# Altium schematic sheet FastRelay.SchDoc
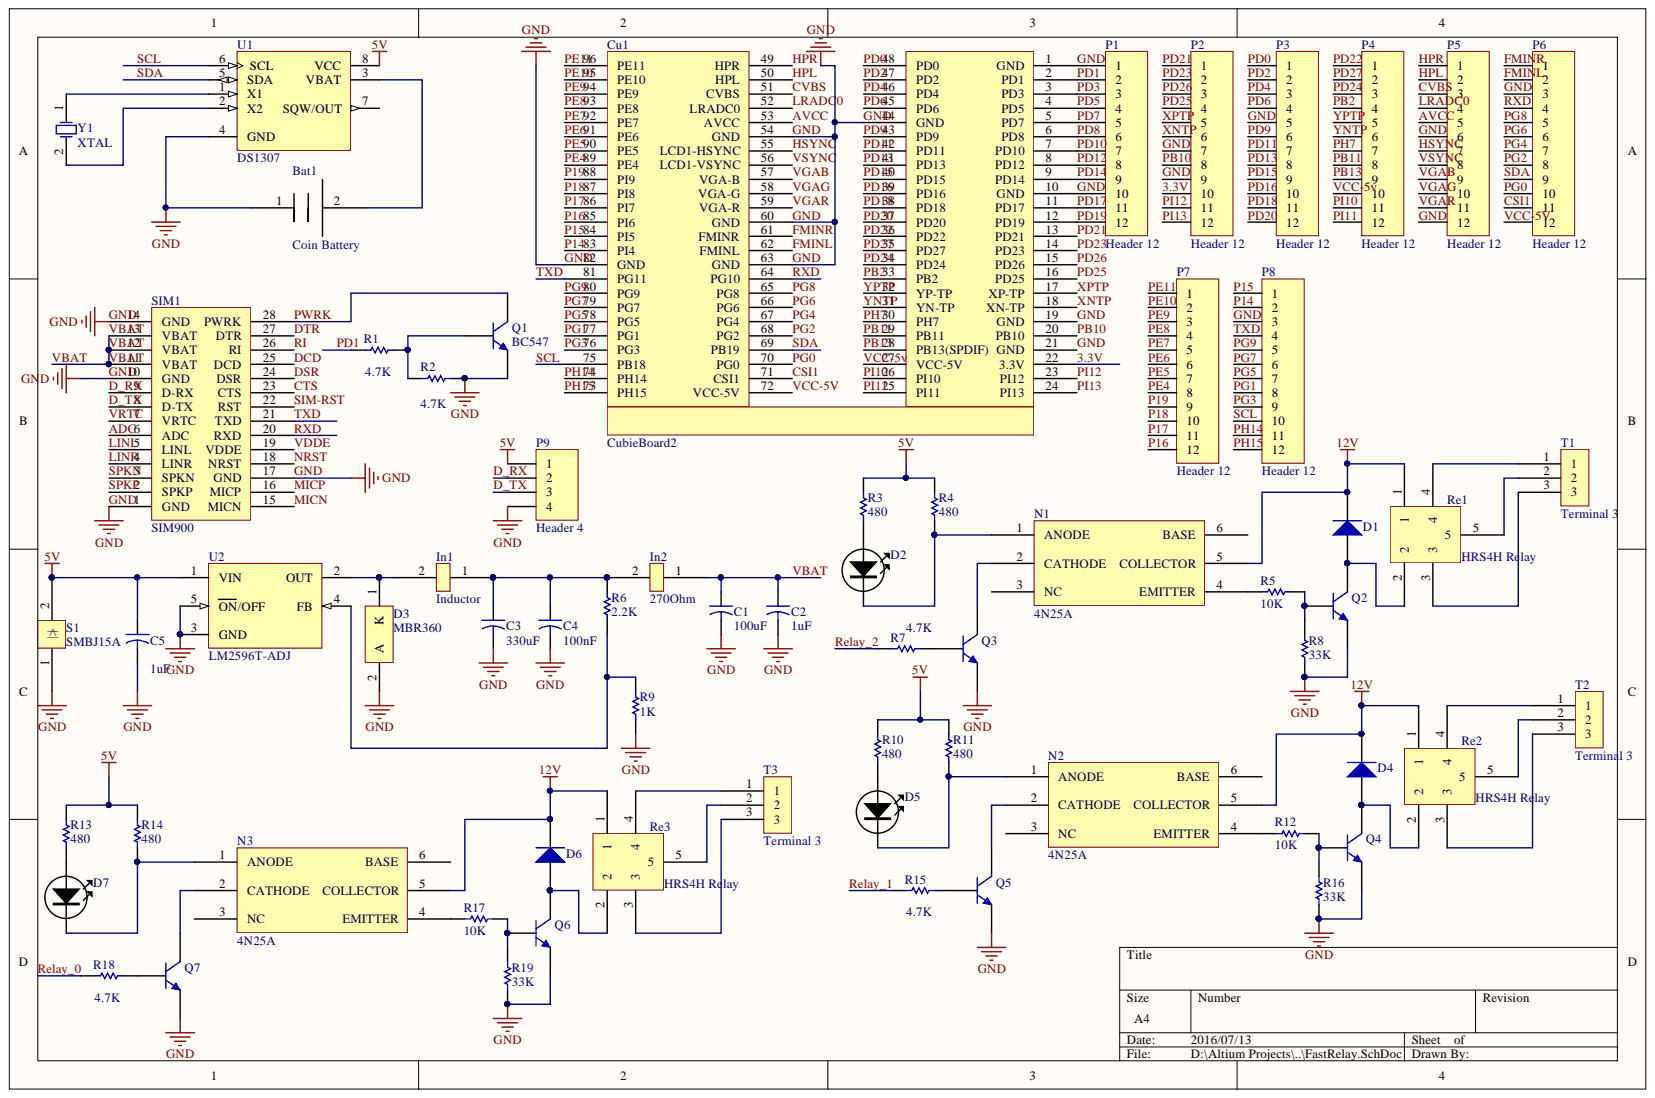
[diagram p0: FastRelay.SchDoc]

## Parts
Bat1  Coin Battery  [CoinBattery]
C1  value 100uF  [CAPR5-4X5]  (hidden comment: Cap2)
C2  value 1uF  [CAPR5-4X5]  (hidden comment: Cap2)
C3  value 330uF  [CAPR5-4X5]  (hidden comment: Cap2)
C4  value 100nF  [CAPR5-4X5]  (hidden comment: Cap2)
C5  value 1uF  [CAPR5-4X5]  (hidden comment: Cap2)
Cu1  CubieBoard2  [CubieBoard2]
D1  Diode 1N4007  [DO-41]  (value not drawn)
D2  LED-GREEN-3MM  [LED-3MM-GREEN]  (value not drawn)
D3  MBR360  [ONSC-AXIAL_LEAD-2-267-05_V]
D4  Diode 1N4007  [DO-41]  (value not drawn)
D5  LED-GREEN-3MM  [LED-3MM-GREEN]  (value not drawn)
D6  Diode 1N4007  [DO-41]  (value not drawn)
D7  LED-GREEN-3MM  [LED-3MM-GREEN]  (value not drawn)
In1  Inductor  [Inductor]
In2  270Ohm  [Inductor]
N1  4N25A  [4N25A]
N2  4N25A  [4N25A]
N3  4N25A  [4N25A]
P1  Header 12  [HDR1X12]
P2  Header 12  [HDR1X12]
P3  Header 12  [HDR1X12]
P4  Header 12  [HDR1X12]
P5  Header 12  [HDR1X12]
P6  Header 12  [HDR1X12]
P7  Header 12  [HDR1X12]
P8  Header 12  [HDR1X12]
P9  Header 4  [HDR1X4]
Q1  BC547  [SOT54]
Q2  BC547  [SOT54]  (value not drawn)
Q3  BC547  [SOT54]  (value not drawn)
Q4  BC547  [SOT54]  (value not drawn)
Q5  BC547  [SOT54]  (value not drawn)
Q6  BC547  [SOT54]  (value not drawn)
Q7  BC547  [SOT54]  (value not drawn)
R1  value 4.7K  [AXIAL-0.3]  (hidden comment: Res1)
R2  value 4.7K  [AXIAL-0.3]  (hidden comment: Res1)
R3  value 480  [AXIAL-0.3]  (hidden comment: Res1)
R4  value 480  [AXIAL-0.3]  (hidden comment: Res1)
R5  value 10K  [AXIAL-0.3]  (hidden comment: Res1)
R6  value 2.2K  [AXIAL-0.3]  (hidden comment: Res1)
R7  value 4.7K  [AXIAL-0.3]  (hidden comment: Res1)
R8  value 33K  [AXIAL-0.3]  (hidden comment: Res1)
R9  value 1K  [AXIAL-0.3]  (hidden comment: Res1)
R10  value 480  [AXIAL-0.3]  (hidden comment: Res1)
R11  value 480  [AXIAL-0.3]  (hidden comment: Res1)
R12  value 10K  [AXIAL-0.3]  (hidden comment: Res1)
R13  value 480  [AXIAL-0.3]  (hidden comment: Res1)
R14  value 480  [AXIAL-0.3]  (hidden comment: Res1)
R15  value 4.7K  [AXIAL-0.3]  (hidden comment: Res1)
R16  value 33K  [AXIAL-0.3]  (hidden comment: Res1)
R17  value 10K  [AXIAL-0.3]  (hidden comment: Res1)
R18  value 4.7K  [AXIAL-0.3]  (hidden comment: Res1)
R19  value 33K  [AXIAL-0.3]  (hidden comment: Res1)
Re1  HRS4H Relay  [HRS4H-Relay]
Re2  HRS4H Relay  [HRS4H-Relay]
Re3  HRS4H Relay  [HRS4H-Relay]
S1  SMBJ15A  [SMBJ15A]
SIM1  SIM900  [SIM900]
T1  Terminal 3  [Terminal3]
T2  Terminal 3  [Terminal3]
T3  Terminal 3  [Terminal3]
U1  DS1307  [DIP8]
U2  LM2596T-ADJ  [T05D]
Y1  XTAL  [R38]

## Nets
5V: C5.1 P9.1 R3.2 R4.2 R10.2 R11.2 R13.2 R14.2 S1.2 U1.8(VCC) U2.1(VIN)
12V: D1.2 D4.2 D6.2 N1.5(COLLECTOR) N2.5(COLLECTOR) N3.5(COLLECTOR) Re1.1 Re2.1 Re3.1
3.3V: Cu1.22(3.3V) P2.10
ADC: SIM1.6(ADC)
AVCC: Cu1.53 P5.5
CSI1: Cu1.71 P6.11
CTS: SIM1.23(CTS)
CVBS: Cu1.51 P5.3
D_RX: P9.2 SIM1.9(D-RX)
D_TX: P9.3 SIM1.8(D-TX)
DCD: SIM1.25(DCD)
DSR: SIM1.24(DSR)
DTR: SIM1.27(DTR)
FMINL: Cu1.62 P6.2
FMINR: Cu1.61(FMINR) P6.1
GND: Bat1.1 C1.2 C2.2 C3.2 C4.2 C5.2 Cu1.1(GND) Cu1.10(GND) Cu1.19(GND) Cu1.21(GND) Cu1.44 Cu1.54(GND) Cu1.60 Cu1.63 Cu1.82(GND) D3.2 P1.1 P1.10 P2.7 P2.9 P3.5 P5.6 P5.12 P6.3 P8.3 P9.4 Q1.1 Q2.1 Q3.1 Q4.1 Q5.1 Q6.1 Q7.1 R2.2 R8.2 R9.1 R16.2 R19.2 S1.1 SIM1.1(GND) SIM1.10(GND) SIM1.14(GND) SIM1.17(GND) U1.4(GND) U2.3(GND) U2.5(ON/OFF)
HPL: Cu1.50 P5.2
HPR: Cu1.49(HPR) P5.1
HSYNC: Cu1.55 P5.7
LINL: SIM1.5(LINL)
LINR: SIM1.4(LINR)
LRADC0: Cu1.52 P5.4
MICN: SIM1.15(MICN)
MICP: SIM1.16(MICP)
NRST: SIM1.18(NRST)
P14: Cu1.83(PI4) P8.2
P15: Cu1.84(PI5) P8.1
P16: Cu1.85(PI6) P7.12
P17: Cu1.86(PI7) P7.11
P18: Cu1.87(PI8) P7.10
P19: Cu1.88(PI9) P7.9
PB2: Cu1.33 P4.4
PB10: Cu1.20(PB10) P2.8
PB11: Cu1.29 P4.8
PB13: Cu1.28 P4.9
PD0: Cu1.48 P3.1
PD1: Cu1.2(PD1) P1.2 R1.1
PD2: Cu1.47 P3.2
PD3: Cu1.3(PD3) P1.3
PD4: Cu1.46 P3.3
PD5: Cu1.4(PD5) P1.4
PD6: Cu1.45 P3.4
PD7: Cu1.5(PD7) P1.5
PD8: Cu1.6(PD8) P1.6
PD9: Cu1.43 P3.6
PD10: Cu1.7(PD10) P1.7
PD11: Cu1.42 P3.7
PD12: Cu1.8(PD12) P1.8
PD13: Cu1.41 P3.8
PD14: Cu1.9(PD14) P1.9
PD15: Cu1.40 P3.9
PD16: Cu1.39 P3.10
PD17: Cu1.11(PD17) P1.11
PD18: Cu1.38 P3.11
PD19: Cu1.12(PD19) P1.12
PD20: Cu1.37 P3.12
PD21: Cu1.13(PD21) P2.1
PD22: Cu1.36 P4.1
PD23: Cu1.14(PD23) P2.2
PD24: Cu1.34 P4.3
PD25: Cu1.16(PD25) P2.4
PD26: Cu1.15(PD26) P2.3
PD27: Cu1.35 P4.2
PE4: Cu1.89(PE4) P7.8
PE5: Cu1.90(PE5) P7.7
PE6: Cu1.91(PE6) P7.6
PE7: Cu1.92(PE7) P7.5
PE8: Cu1.93(PE8) P7.4
PE9: Cu1.94(PE9) P7.3
PE10: Cu1.95(PE10) P7.2
PE11: Cu1.96(PE11) P7.1
PG0: Cu1.70 P6.10
PG1: Cu1.77(PG1) P8.8
PG2: Cu1.68 P6.8
PG3: Cu1.76(PG3) P8.9
PG4: Cu1.67 P6.7
PG5: Cu1.78(PG5) P8.7
PG6: Cu1.66(PG6) P6.6
PG7: Cu1.79(PG7) P8.6
PG8: Cu1.65 P6.5
PG9: Cu1.80(PG9) P8.5
PH7: Cu1.30 P4.7
PH14: Cu1.74(PH14) P8.11
PH15: Cu1.73(PH15) P8.12
PI10: Cu1.26 P4.11
PI11: Cu1.25 P4.12
PI12: Cu1.23(PI12) P2.11
PI13: Cu1.24(PI13) P2.12
PWRK: Q1.3 SIM1.28(PWRK)
RELAY_0: R18.2
RELAY_1: R15.2
RELAY_2: R7.2
RI: SIM1.26(RI)
RXD: Cu1.64(RXD) P6.4 SIM1.20(RXD)
SCL: Cu1.75(PB18) P8.10 U1.6(SCL)
SDA: Cu1.69(SDA) P6.9 U1.5(SDA)
SIM-RST: SIM1.22(RST)
SPKN: SIM1.3(SPKN)
SPKP: SIM1.2(SPKP)
TXD: Cu1.81(PG11) P8.4 SIM1.21(TXD)
VBAT: C1.1 C2.1 In2.1 SIM1.11(VBAT) SIM1.12(VBAT) SIM1.13(VBAT)
VCC-5V: Cu1.27 Cu1.72 P4.10 P6.12
VDDE: SIM1.19(VDDE)
VGAB: Cu1.57 P5.9
VGAG: Cu1.58 P5.10
VGAR: Cu1.59(VGAR) P5.11
VRTC: SIM1.7(VRTC)
VSYNC: Cu1.56 P5.8
XNTP: Cu1.18(XN-TP) P2.6
XPTP: Cu1.17(XP-TP) P2.5
YNTP: Cu1.31 P4.6
YPTP: Cu1.32 P4.5
NetBat1_2: Bat1.2 U1.3(VBAT)
NetC3_1: C3.1 C4.1 In1.1 In2.2 R6.2
NetD1_1: D1.1 Q2.3 Re1.2
NetD2_A: D2.A R3.1
NetD2_K: D2.K N1.1(ANODE) R4.1
NetD3_1: D3.1 In1.2 U2.2(OUT)
NetD4_1: D4.1 Q4.3 Re2.2
NetD5_A: D5.A R10.1
NetD5_K: D5.K N2.1(ANODE) R11.1
NetD6_1: D6.1 Q6.3 Re3.2
NetD7_A: D7.A R13.1
NetD7_K: D7.K N3.1(ANODE) R14.1
NetN1_2: N1.2(CATHODE) Q3.3
NetN1_4: N1.4(EMITTER) R5.2
NetN2_2: N2.2(CATHODE) Q5.3
NetN2_4: N2.4(EMITTER) R12.2
NetN3_2: N3.2(CATHODE) Q7.3
NetN3_4: N3.4(EMITTER) R17.2
NetQ1_2: Q1.2 R1.2 R2.1
NetQ2_2: Q2.2 R5.1 R8.1
NetQ3_2: Q3.2 R7.1
NetQ4_2: Q4.2 R12.1 R16.1
NetQ5_2: Q5.2 R15.1
NetQ6_2: Q6.2 R17.1 R19.1
NetQ7_2: Q7.2 R18.1
NetR6_1: R6.1 R9.2 U2.4(FB)
NetRe1_3: Re1.3 T1.3
NetRe1_4: Re1.4 T1.1
NetRe1_5: Re1.5 T1.2
NetRe2_3: Re2.3 T2.3
NetRe2_4: Re2.4 T2.1
NetRe2_5: Re2.5 T2.2
NetRe3_3: Re3.3 T3.3
NetRe3_4: Re3.4 T3.1
NetRe3_5: Re3.5 T3.2
NetU1_1: U1.1(X1) Y1.1
NetU1_2: U1.2(X2) Y1.2

Not connected: N1 3(NC) 6(BASE); N2 3(NC) 6(BASE); N3 3(NC) 6(BASE); U1 7(SQW/OUT)

## Library data of the parts
C1-C5 = Cap2: Capacitor; Component Kind: Standard; Library Name: Miscellaneous Devices.IntLib; PackageDescription: Capacitor; 2 Leads; PackageReference: CAPR5-4X5; Published: 23-Sep-2002; Signal Integrity: Cap; Simulation: CAP; Publisher: Altium Limited
D1 D4 D6 = Diode 1N4007: 1 Amp General Purpose Rectifier; Code_JEDEC: DO-204-AL; Component Kind: Standard; ComponentLink1Description: Manufacturer Link; ComponentLink1URL: http://www.fairchildsemi.com/; ComponentLink2Description: Datasheet; ComponentLink2URL: http://www.fairchildsemi.com/ds/1N%2F1N4001.pdf; DatasheetVersion: 1998; LatestRevisionDate: 17-Jul-2002; LatestRevisionNote: Re-released for DXP Platform.; Library Name: Miscellaneous Devices.IntLib; PackageDescription: Diode, Axial; Body 5.2 x 2.7 mm (LxDia. max), Lead Dia. 0.86 mm (max); PackageReference: DO-41; PackageVersion: Aug-1999; Published: 8-Jun-2000; Signal Integrity: 1N4007; Simulation: 1N4007; Publisher: Altium Limited
D3 = MBR360: Rectifier, 2-Pin Axial_Lead, Bulk Bag; Manufacturer: On Semiconductor; Configuration: Single; IFSM Max (A): 80; Component Kind: Standard; ComponentLink1Description: Manufacturer URL; ComponentLink1URL: http://www.onsemi.com/; ComponentLink2Description: Datasheet; ComponentLink2URL: http://www.onsemi.cn/pub_link/Collateral/MBR350-D.PDF; ComponentLink3Description: Package Specification; ComponentLink3URL: http://www.onsemi.com/pub_link/Collateral/267-05.PDF; DatasheetVersion: Rev. 6; Library Name: ON Semi Schottky Diodes & Schottky Rectifiers.IntLib; Mounting Technology: Through Hole; PackageDescription: 2-Pin, Axial Lead, Diameter 5.05 mm; PackageReference: AXIAL_LEAD-2-267-05; PackageVersion: Rev. G, 06/2000; Packing: Bulk Bag; PartNumber: MBR360; RoHS: FALSE; Supplier 1: Digi-Key; Supplier Part Number 1: MBR360OS-ND
In1 = Inductor: 100uF; Component Kind: Standard; Library Name: Inductor.SchLib
In2 = Inductor: Component Kind: Standard; Description: Inductor; Library Name: Inductor.SchLib
P1-P8 = Header 12: Header, 12-Pin; Component Kind: Standard; LatestRevisionDate: 17-Jul-2002; LatestRevisionNote: Re-released for DXP Platform.; Library Name: Miscellaneous Connectors.IntLib; Signal Integrity: Connector; Publisher: Altium Limited
P9 = Header 4: Header, 4-Pin; Component Kind: Standard; LatestRevisionDate: 17-Jul-2002; LatestRevisionNote: Re-released for DXP Platform.; Library Name: Miscellaneous Connectors.IntLib; Signal Integrity: Connector; Publisher: Altium Limited
Q1-Q7 = BC547: NPN General-purpose Transistor; Code_JEDEC: TO-92; Code_JEITA: SC-43; Component Kind: Standard; ComponentLink1Description: Manufacturer Link; ComponentLink1URL: http://www.semiconductors.philips.com/; ComponentLink2Description: Datasheet; ComponentLink2URL: http://www.semiconductors.philips.com/acrobat/datasheets/BC546_547_3.pdf; DatasheetVersion: 15-Apr-1999; LatestRevisionDate: 12-Dec-2006; LatestRevisionNote: Footprint pad sequence fixed.; Library Name: Philips Discrete BJT - Low Power.IntLib; PackageDescription: Plastic Single-Ended Leaded (through hole) package; 3 Leads (TO-92); PackageReference: SOT54; PackageVersion: Feb-1997; Published: 17-Sep-2003; Signal Integrity: BC547; Publisher: Altium Limited
R1-R19 = Res1: Resistor; Component Kind: Standard; LatestRevisionDate: 17-Jul-2002; LatestRevisionNote: Re-released for DXP Platform.; Library Name: Miscellaneous Devices.IntLib; PackageDescription: Axial Device, Thru-Hole; 2 Leads; 0.3 in Pin Spacing; PackageReference: AXIAL-0.3; Published: 8-Jun-2000; Signal Integrity: Res; Simulation: RESISTOR; Publisher: Altium Limited
U1 = DS1307: 64 X 8 Serial Real-Time Clock; Component Kind: Standard; ComponentLink1Description: Manufacturer Link; ComponentLink1URL: http://www.maxim-ic.com/; ComponentLink2Description: Datasheet; ComponentLink2URL: http://pdfserv.maxim-ic.com/en/ds/DS1307.pdf; ComponentLink3Description: Discontinuation Notice; ComponentLink3URL: http://www.maxim-ic.com/qa/pdfs/non-obsolescence-letter.pdf; DatasheetVersion: 10-Aug-1999; LatestRevisionDate: 17-Jul-2002; LatestRevisionNote: Re-released for DXP Platform.; Library Name: Dallas Peripheral Real Time Clock.IntLib; PackageDescription: DIP, 8-Pin, Row Spacing 7.62 mm, Pitch 2.54 mm; PackageReference: DIP8; PackageVersion: 1999; Published: 13-Oct-2000; Publisher: Altium Limited
U2 = LM2596T-ADJ: SIMPLE SWITCHER® Power Converter 150 KHz 3A Step-Down Voltage Regulator, 5-pin TO-220; Manufacturer: National Semiconductor; High-Reliability: Y; PackageTopMarking: NSUZXYTTE#*LM2596T*-ADJ P+; PowerWise: N; Component Kind: Standard; ComponentLink1Description: Manufacturer URL; ComponentLink1URL: http://www.national.com/; ComponentLink2Description: LM2596 Datasheet; ComponentLink2URL: http://www.national.com/ds/LM/LM2596.pdf; ComponentLink3Description: LM2596 Web Page; ComponentLink3URL: http://www.national.com/pf/LM/LM2596.html; ComponentLink4Description: T05D Package Page; ComponentLink4URL: http://www.national.com/packaging/folders/t05d.html; CompType: Switcher; DatasheetVersion: May-2002; Library Name: National Semiconductor Switcher.IntLib; PackageDescription: TO-220, Molded, Stagger Bend, 5 Lead; PackageReference: T05D; PackageVersion: revA, Oct-1989; PartNumber: LM2596T-ADJ; PinCount: 5; RoHS: N
Y1 = XTAL: Crystal Oscillator; Component Kind: Standard; LatestRevisionDate: 17-Jul-2002; LatestRevisionNote: Re-released for DXP Platform.; Library Name: Miscellaneous Devices.IntLib; PackageDescription: Crystal, Thru-Hole; 2 Leads; Body 3.1 x 8.2 mm (Dia.xH); PackageReference: R38; Published: 8-Jun-2000; Simulation: XTAL; Publisher: Altium Limited
Bat1 = Coin Battery: Component Kind: Standard; Description: Coin Battery; Library Name: CoinBattery.SchLib
Cu1 = CubieBoard2: Component Kind: Standard; Description: CubieBoard2; Library Name: CubieBoard2.SchLib
D2 D5 D7 = LED-GREEN-3MM: Component Kind: Standard; Description: LED-GREEN-3MM; Library Name: vh_altium_library.intLib
N1-N3 = 4N25A: Component Kind: Standard; Description: 4N25A; Library Name: 4N25A.SchLib
Re1-Re3 = HRS4H Relay: Component Kind: Standard; Description: HRS4H Relay; Library Name: HRS4H-Relay.SchLib
S1 = SMBJ15A: Component Kind: Standard; Description: SMBJ15A; Library Name: SMBJ15A.SchLib
SIM1 = SIM900: Component Kind: Standard; Description: SIM900; Library Name: SIM900.SchLib
T1-T3 = Terminal 3: Component Kind: Standard; Library Name: Terminal3.SchLib
